# Altium schematic sheet [Saberstick] AtMega328P.SchDoc
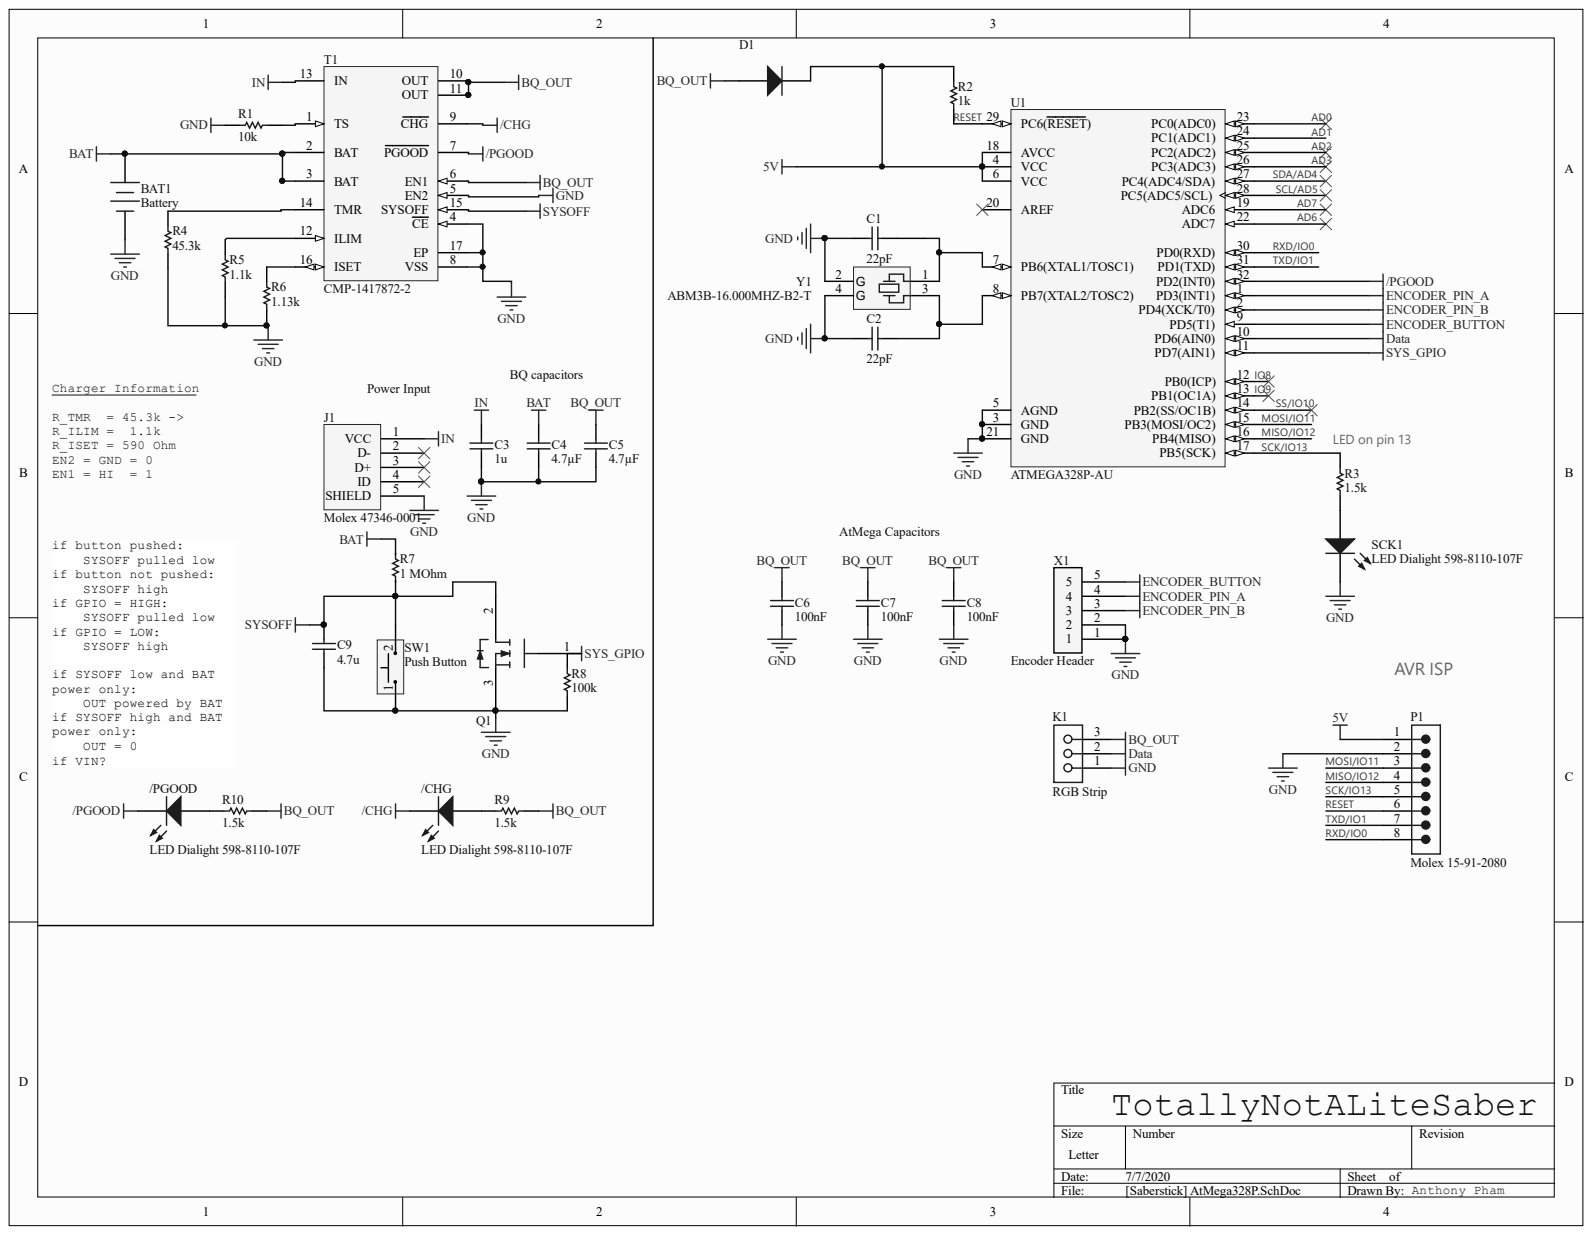
[diagram p0: [Saberstick] AtMega328P.SchDoc]

## Parts
/CHG  LED Dialight 598-8110-107F  [PCB-9ifma0oh53wy532w7hco-1]
/PGOOD  LED Dialight 598-8110-107F  [PCB-9ifma0oh53wy532w7hco-1]
BAT1  Battery  [PCB-nmv0vzhkfwsbxqx6kc1g-1]
C1  22pF  [C0805]
C2  22pF  [C0805]
C3  1u  [CAPC1608X90X35LL15T15]
C4  4.7µF  [CAPC1608X90X35LL15T15]
C5  4.7µF  [CAPC1608X90X35LL15T15]
C6  100nF  [PCB-2cfusdo6sp8ecp4m660c-1]
C7  100nF  [PCB-2cfusdo6sp8ecp4m660c-1]
C8  100nF  [PCB-2cfusdo6sp8ecp4m660c-1]
C9  4.7u  [CAPC2012X11N]
D1  ON Semiconductor MURS260T3G  [PCB-jkdndedyhvwjcy08whko-1]  (value not drawn)
J1  Molex 47346-0001  [PCB-lw6upt0guqv556jll6nj-1]
K1  RGB Strip  [HDR-3RA-M]
P1  Molex 15-91-2080  Manufacturer Part Number: 15-91-2080  [PCB-9z2s1xi4wyp5b0d33itb-1]
Q1  N-Channel MOSFET  [ONSC-TO-220-3-221A-09]  (value not drawn)
R1  10k  [PCB-lfoq2zshv8871jnijg7w-1]
R2  1k  [PCB-bxx9w8jzwpp640jjc58j-1]
R3  1.5k  [RESC2013X60X30NL20T20]
R4  45.3k  [PCB-15394kepv9f7eff3yv9i-1]
R5  1.1k  [RESC2013X70X40LL20T20]
R6  1.13k  [RESC2013X50X30NL20T20]
R7  1 MOhm  [RESC2013X70X40NL20T20]
R8  100k  [RESC2013X60X35LL10T20]
R9  1.5k  [RESC2013X60X30NL20T20]
R10  1.5k  [RESC2013X60X30NL20T20]
SCK1  LED Dialight 598-8110-107F  [PCB-9ifma0oh53wy532w7hco-1]
SW1  Push Button  [PCB-ig79pzg5mdpht1qqvy53-1]
T1  CMP-1417872-2  [RGT16-1700X1700TP]
U1  ATMEGA328P-AU  [PCB-pmhtxxix7sripjdh33vl-1]
X1  Encoder Header  [PCB-y3ve9b8dylm0s3m8lnid-1]
Y1  ABM3B-16.000MHZ-B2-T  [ABCN-ABM3B]

## Nets
5V: D1.2 P1.1 R2.2 U1.4(VCC) U1.6(VCC) U1.18(AVCC)
/CHG: /CHG.1 T1.9(CHG)
/PGOOD: /PGOOD.1 T1.7(PGOOD) U1.32(PD2(INT0))
BAT: BAT1.1 C4.2 R7.2 T1.2(BAT) T1.3(BAT)
BQ_OUT: C5.2 C6.2 C7.2 C8.2 D1.1 K1.3 R9.2 R10.2 T1.6(EN1) T1.10(OUT) T1.11(OUT)
DATA: K1.2 U1.10(PD6(AIN0))
ENCODER_BUTTON: U1.9(PD5(T1)) X1.5
ENCODER_PIN_A: U1.1(PD3(INT1)) X1.4
ENCODER_PIN_B: U1.2(PD4(XCK/T0)) X1.3
GND: BAT1.2 C1.1 C2.1 C3.1 C4.1 C5.1 C6.1 C7.1 C8.1 C9.1 J1.5(SHIELD) K1.1 P1.2 Q1.3 R1.1 R4.1 R5.1 R6.1 R8.1 SCK1.1 SW1.1 T1.4(CE) T1.5(EN2) T1.8(VSS) T1.17(EP) U1.3(GND) U1.5(AGND) U1.21(GND) X1.1 X1.2 Y1.2(G) Y1.4(G)
IN: C3.2 J1.1(VCC) T1.13(IN)
SYS_GPIO: R8.2 U1.11(PD7(AIN1))
SYSOFF: C9.2 R7.1 SW1.2 T1.15(SYSOFF)
AD0: U1.23(PC0(ADC0))
AD1: U1.24(PC1(ADC1))
AD2: U1.25(PC2(ADC2))
AD3: U1.26(PC3(ADC3))
AD6: U1.22(ADC7)
AD7: U1.19(ADC6)
IO8: U1.12(PB0(ICP))
IO9: U1.13(PB1(OC1A))
MISO/IO12: P1.4 U1.16(PB4(MISO))
MOSI/IO11: P1.3 U1.15(PB3(MOSI/OC2))
RESET: P1.6 R2.1 U1.29(PC6(RESET))
RXD/IO0: P1.8 U1.30(PD0(RXD))
SCK/IO13: P1.5 R3.2 U1.17(PB5(SCK))
SCL/AD5: U1.28(PC5(ADC5/SCL))
SDA/AD4: U1.27(PC4(ADC4/SDA))
SS/IO10: U1.14(PB2(SS/OC1B))
TXD/IO1: P1.7 U1.31(PD1(TXD))
Net/CHG_2: /CHG.2 R9.1
Net/PGOOD_2: /PGOOD.2 R10.1
NetC1_2: C1.2 U1.7(PB6(XTAL1/TOSC1)) Y1.1
NetC2_2: C2.2 U1.8(PB7(XTAL2/TOSC2)) Y1.3
NetR1_2: R1.2 T1.1(TS)
NetR3_1: R3.1 SCK1.2
NetR4_2: R4.2 T1.14(TMR)
NetR5_2: R5.2 T1.12(ILIM)
NetR6_2: R6.2 T1.16(ISET)

Not connected: J1 2(D-) 3(D+) 4(ID); Q1 1 2; U1 20(AREF)

## Library data of the parts
/CHG /PGOOD = 598-8110-107F: 598-8110-107F, Dialight, SMD LED 0805 Package, Red Single color SOLD IN INCREMENTS OF 4000. (REEL); Lead-Free Status: Lead Free; Wavelength: 635 nm, 642 nm; Color: Red; Component Kind: Standard; Lens Color: Red; Library Name: Octopart library; Mounting Style: Surface Mount, Vertical; Number of Pins: 2; Octopart URL: https://octopart.com/598-8110-107f-dialight-868569; Size-Height: 700 µm; Size-Width: 1.25 mm
BAT1 = 1043P: Pcb Holder Thm 18650 Polarized; Component Kind: Standard; Library Name: Octopart library; Lifecycle Status: Not Listed by Manufacturer; Octopart URL: http://octopart.com/1043p-keystone-31888867
C1 C2 = CC0805JRNPO9BN220: 0805 22 pF 50 V ±5 % Tolerance NP0 SMT Multilayer Ceramic Capacitor; Capacitance: 2.2e-11 F; Capacitance Tolerance: ±5%; Dielectric Characteristic: C0G/NP0; Lead-Free Status: Lead Free; Voltage Rating (DC): 50.0 V; Case/Package: 0805; Component Kind: Standard; Dielectric Material: Ceramic; Halogen Free Status: Halogen Free; Library Name: Octopart library; Manufacturer Part Number: CC0805JRNPO9BN220; Mounting Style: Surface Mount; Number of Pins: 2; OctopartID: 05bece31d998da79; Octopart Url: http://octopart.com/cc0805jrnpo9bn220-yageo-42334528; Packaging: Cut Tape (CT); REACH SVHC Compliance: No SVHC; RoHS: Compliant; Seller Landing  Url: https://octopart-clicks.com/click/track?country=US&ak=8157f166&sig=0a0fff6&sid=5489&ppid=42334528&vpid=123646948&ct=offers
C3 = 06036D105KAT2A: 0603 1 uF 6.3 V ±10 % Tolerance X5R Surface Mount Multilayer Ceramic Capacitor; Manufacturer: AVX; Capacitance Tolerance: ±10%; Component Kind: Standard; Library Name: Octopart library; Packaging: Tape & Reel (TR), Reel, Cut Tape (CT); Size-Height: 860 µm
C4 C5 = CC0603KRX5R6BB475: 0603 4.7 uF 10 V ±10 % Tolerance X5R SMT Multilayer Ceramic Capacitor; Capacitance: 4.70 µF; Capacitance Tolerance: ±10%; Lead-Free Status: Lead Free; Voltage Rating (DC): 10.0 V; Component Kind: Standard; Dielectric Characteristic: X5R; Library Name: Octopart library; Mounting Style: Surface Mount; Number of Pins: 2; OctopartID: 876b4eec68d658ac; Octopart URL: https://octopart.com/cc0603krx5r6bb475-yageo-9292507; Operating Temperature: -55.0 °C to 85.0 °C; RoHS: Compliant; Simulation: SIM-zlfqd2zohxpm5c17x9mi-1
C6-C8 = 08051C104K4T2A: CAP CER 0.1UF 100V 10% X7R 0805; Capacitance: 1e-07 F; Capacitance Tolerance: ±10%; Component Kind: Standard; Dielectric Characteristic: X7R; Library Name: Octopart library; Manufacturer Part Number: 08051C104K4T2A; Mounting Style: Surface Mount; Number of Pins: 2; OctopartID: a55e6c3878157a99; REACH SVHC Compliance: No SVHC; RoHS: Compliant
C9 = GRM21BR61C475KA88L: 0805 4.7 uF 16 V ±10% Tolerance X5R SMT Multilayer Ceramic Capacitor; Capacitance: 4.7e-06 F; Case/Package (SI): 2012; Lead-Free Status: Lead Free; Voltage Rating (DC): 16.0 V; Capacitance Tolerance: ±10%; Case/Package: 0805, 2012; Component Kind: Standard; Dielectric Characteristic: X5R; Library Name: Octopart library; Lifecycle Status: Active; Manufacturer Part Number: GRM21BR61C475KA88L; Mounting Style: Surface Mount; OctopartID: 11a0d8a9359bce67; Octopart URL: http://octopart.com/grm21br61c475ka88l-murata-196957; Packaging: Cut Tape (CT), Tape & Reel (TR); REACH SVHC Compliance: No SVHC; RoHS: Compliant; Size-Height: 0.00125 m; Size-Length: 0.002 m; Size-Thickness: 0.00125 m; Size-Width: 0.00125 m
D1 = MURS260T3G: MURS Series 2 A 600 V 75 ns Surface Mount Ultrafast Power Rectifier - CASE-403A; Component Kind: Standard; Library Name: Octopart library
J1 = CMP-2328464-13: Micro-USB B Receptacle with Flange, Bottom Mount, Surface Mount, Lead-Free; Component Kind: Standard; Library Name: CommunityVault
K1 = 61300311021: Board-To-Board Connector, Right Angle, WR-PHD Series, Through Hole, Header, 3, 2.54 mm; Gender: Male; Number of Contacts: 3; Component Kind: Standard; Contact Material: Copper; Contact Plating: Gold; Library Name: Octopart library; Manufacturer Part Number: 61300311021; Mounting Style: Through Hole; OctopartID: 812e49d1f1a85ee9; Octopart URL: http://octopart.com/61300311021-w%C3%BCrth+elektronik-32855520; REACH SVHC Compliance: No SVHC; RoHS: Compliant
P1 = CMP-0037c9391c13dff0-2: Conn Unshrouded Header HDR 8 POS 2.54mm Solder ST SMD C-Grid Tube; Current Rating: 3.00 A; ELV Compliant: Compliant; Glow Wire Compliant: Non-Compliant; Lead-Free Status: Lead Free; Number of Circuits: 7, 8; Number of Contacts: 8; Voltage Rating (DC): 250 V; Component Kind: Standard; Contact Material: Phosphor Bronze; Contact Plating: Tin; Flammability Rating: UL 94 V-0; Halogen Free Status: Not Halogen Free; Housing Color: Black; Housing Material: Plastic; Library Name: CommunityVault; Lifecycle Status: Active; Material: Phosphor Bronze; Mounting Style: Surface Mount, PC Board, Vertical; Number of Positions: 8; Number of Rows: 2; OctopartID: 0037c9391c13dff0; Octopart URL: https://octopart.com/15-91-2080-molex-276802; Operating Temperature: -40.0 °C to 105 °C; Orientation: Vertical; Packaging: Tube; Pin Pitch: 2.54 mm; REACH SVHC Compliance: No SVHC; RoHS: Compliant; Size-Length: 10.2 mm; Size-Width: 5.08 mm
Q1 = CSD18532KCS: MOSFET N-CH 60V 100A TO220-3; Lead-Free Status: Contains Lead; Component Kind: Standard; Library Name: Octopart library; Lifecycle Status: Active; Manufacturer Part Number: CSD18532KCS; Number of Pins: 3; OctopartID: 2faee7f7ce7ff069; Octopart Url: https://octopart.com/csd18532kcs-texas+instruments-22267063; Polarity: N-Channel; RoHS: Non-Compliant
R1 = CMP-1854303-35: Res Thick Film 0805 10K Ohm 1% 0.125W(1/8W) +/-100ppm/C Epoxy Pad SMD T/R; Manufacturer Part Number: RC0805FR-0710KL; Case/Package (SI): 2012; Lead-Free Status: Lead Free; Resistance: 10.0 kΩ; Temperature Coefficient: -100 ppm/°C to 100 ppm/°C; Voltage Rating (DC): 150 V; Component Kind: Standard; Library Name: CommunityVault; Mounting Style: Surface Mount; Number of Pins: 2; Octopart URL: https://octopart.com/rc0805fr-0710kl-yageo-40301103; REACH SVHC Compliance: No SVHC; RoHS: Compliant
R2 = ERJ-P06F1001V: Res Thick Film 0805 1K Ohm 1% 0.25W ±100ppm/°C Molded SMD Punched Carrier T/R; Lead-Free Status: Lead Free; Temperature Coefficient: -100 ppm/°C to 100 ppm/°C; Case/Package: 2012, 0805; Component Kind: Standard; Library Name: Octopart library; Manufacturer Part Number: ERJ-P06F1001V; Mounting Style: Surface Mount; Number of Pins: 2; Packaging: Cut Tape (CT), Tape & Reel (TR); REACH SVHC Compliance: No SVHC; RoHS: Compliant
R3 R9 R10 = SG73P2ATTD1501F: Thick Film Resistors - SMD 0.25W 1.5Kohm 1% 200ppm / Learn More; Case/Package (SI): 2012; Resistance: 1500.0 Ω; Temperature Coefficient: 200.0 ppm/°C; Voltage Rating (DC): 150.0 V; Case/Package: 0805; Component Kind: Standard; Library Name: Octopart library; Manufacturer Part Number: SG73P2ATTD1501F; Number of Pins: 2; OctopartID: 4c1d8561534f93da; Octopart Url: https://octopart.com/sg73p2attd1501f-koa+speer-7748148; Power Rating: 0.25 W; RoHS: Compliant
R4 = CRCW080545K3FKEA: Res Thick Film 0805 45.3K Ohm 1% 0.125W(1/8W) ±100ppm/C Molded SMD Automotive Paper T/R; Lead-Free Status: Lead Free; Component Kind: Standard; Library Name: Octopart library; Manufacturer Part Number: CRCW080545K3FKEA; Mounting Style: Surface Mount; OctopartID: e76d2c3890db38aa; Octopart URL: http://octopart.com/crcw080545k3fkea-vishay+dale-39801552; REACH SVHC Compliance: No SVHC
R5 = ERJ-6ENF1101V: Thick Film Resistors - SMD 0805 1.1Kohms 1% Tol; Case/Package (SI): 2012; Lead-Free Status: Lead Free; Power Rating: 0.125 W; Resistance: 1100.0 Ω; Voltage Rating (DC): 150.0 V; Case/Package: 0805; Component Kind: Standard; Library Name: Octopart library; Lifecycle Status: Not Listed by Manufacturer; Manufacturer Part Number: ERJ-6ENF1101V; Mounting Style: Surface Mount; OctopartID: 3600fc47be10e9c7; Octopart Url: https://octopart.com/erj-6enf1101v-panasonic-55422326; Packaging: Cut Tape (CT); REACH SVHC Compliance: No SVHC; Resistance Tolerance: ±1%; RoHS: Compliant; Size-Height: 0.0006 m; Size-Length: 0.002 m; Size-Width: 0.00125 m
R6 = CRCW08051K13FKEA: RES SMD 1.13K OHM 1% 1/8W 0805; Case/Package (SI): 2012; Lead-Free Status: Lead Free; Power Rating: 0.125 W; Resistance: 1130.0 Ω; Temperature Coefficient: 100.0 ppm/°C; Voltage Rating (DC): 150.0 V; Case/Package: 0805; Component Kind: Standard; Library Name: Octopart library; Manufacturer Part Number: CRCW08051K13FKEA; Mounting Style: Surface Mount; Number of Pins: 2; OctopartID: 58a893c2246f876f; Octopart Url: https://octopart.com/crcw08051k13fkea-vishay+dale-39868833; REACH SVHC Compliance: No SVHC; Resistance Tolerance: ±1%; RoHS: Compliant; Size-Height: 0.00045 m; Size-Length: 0.002 m; Size-Width: 0.00125 m
R7 = ERJ-6ENF1004V: Thick Film Resistors 0805 1Mohms 1% Tol; Case/Package (SI): 2012; Lead-Free Status: Lead Free; Power Rating: 0.125 W; Resistance: 1000000.0 Ω; Voltage Rating (DC): 150.0 V; Case/Package: 0805; Component Kind: Standard; Library Name: Octopart library; Lifecycle Status: Not Listed by Manufacturer; Manufacturer Part Number: ERJ-6ENF1004V; Mounting Style: Surface Mount; Number of Pins: 2; OctopartID: 6b86a1c12a42b441; Octopart Url: https://octopart.com/erj-6enf1004v-panasonic-55403682; Packaging: Cut Tape (CT); REACH SVHC Compliance: No SVHC; Resistance Tolerance: ±1%; RoHS: Compliant; Size-Height: 0.0006 m; Size-Length: 0.002 m; Size-Width: 0.00125 m
R8 = RC0805FR-07100KL: Res Thick Film 0805 100K Ohm 1% 0.125W(1/8W} 100ppm/Molded SMD Paper T/R; Component Kind: Standard; Library Name: Octopart library
SCK1 = CMP-1071566-9: 598-8110-107F, Dialight, SMD LED 0805 Package, Red Single color SOLD IN INCREMENTS OF 4000. (REEL); Lead-Free Status: Lead Free; Wavelength: 635 nm, 642 nm; Color: Red; Component Kind: Standard; Lens Color: Red; Library Name: CommunityVault; Mounting Style: Surface Mount, Vertical; Number of Pins: 2; Octopart URL: https://octopart.com/598-8110-107f-dialight-868569; Size-Height: 700 µm; Size-Width: 1.25 mm
SW1 = 1825910-6: Switch Tactile OFF (ON) SPST Round Button PC Pins 0.05A 24VDC 100000Cycles 1.57N Thru-Hole Loose; Component Kind: Standard; Library Name: Octopart library
T1 = CMP-1417872-2: USB-Friendly Li-Ion Battery Charger and Power-Path Management IC, Vout 5.5 V, 4.3 V, -40 to 85 degC, 16-pin QFN (RGT), Green (RoHS & no Sb/Br); Manufacturer Part Number: BQ24075RGTR; Lead-Free Status: Lead Free; Component Kind: Standard; Library Name: CommunityVault; Lifecycle Status: Active; Number of Pins: 16; OctopartID: ef27269433e930e0; Octopart Url: https://octopart.com/bq24075rgtr-texas+instruments-12188072; RoHS: Compliant
U1 = ATMEGA328P-AU: MCU 8-bit ATmega AVR RISC 32KB Flash 2.5V/3.3V/5V 32-Pin TQFP; RAM Memory Size: 2048 B; Component Kind: Standard; Library Name: Octopart library
X1 = 61300511021: Board-To-Board Connector, Right Angle, WR-PHD Series, Through Hole, Header, 5, 2.54 mm; Gender: Male; Number of Contacts: 5; Component Kind: Standard; Contact Material: Copper; Contact Plating: Gold; Library Name: Octopart library; Manufacturer Part Number: 61300511021; Mounting Style: Through Hole; OctopartID: 2981e17e5902f646; Octopart URL: http://octopart.com/61300511021-w%C3%BCrth+elektronik-32855525; REACH SVHC Compliance: No SVHC; RoHS: Compliant
Y1 = CMP-780013-1: CRYSTAL 16.000MHZ 18PF SMD; Associated Product: 535-10138-ND- SOCKET 4PAD 5.0X3.2 XTAL OR OSC535-10137-ND- SOCKET 4PAD 5.0X3.2 XTAL OR OSC535-10136-ND- SOCKET 4PAD 5.0X3.2 XTAL OR OSC535-10135-ND- SOCKET 4PAD 5.0X3.2 XTAL OR OSC535-10134-ND- SOCKET 4PAD 5.0X3.2 XTAL OR OSC; Catalog Drawings: ABM3B Series TopABM3B Series SideABM3B Series FootprintABM3B Series Circuit; CiivaID: 780013; Dynamic Catalog: ABM3B Series; ESR (Equivalent Series Resistance): 50 Ohm; Frequency: 16MHz; Frequency Stability: ±50ppm; Frequency Tolerance: ±20ppm; Operating Mode: Fundamental; Other Names: 535-9122-6; Category: Crystals and Oscillators; Ciiva URL: https://ciiva.com/part/ABM3B-16.000MHZ-B2-T-780013; Component Kind: Standard; Family: Crystals; Height: 0.043" (1.10mm); Library Name: CommunityVault; Load Capacitance: 18pF; Manufacturer Part Number: ABM3B-16.000MHZ-B2-T; Mounting Type: Surface Mount; Operating Temperature: -20°C ~ 70°C; Package / Case: 4-SMD, No Lead (DFN, LCC); Packaging: Digi-Reel®; Series: ABM3B; Size / Dimension: 0.197" L x 0.126" W (5.00mm x 3.20mm); Standard Package: 1; Type: MHz Crystal
